# Altium schematic sheet RX Schematics.SchDoc
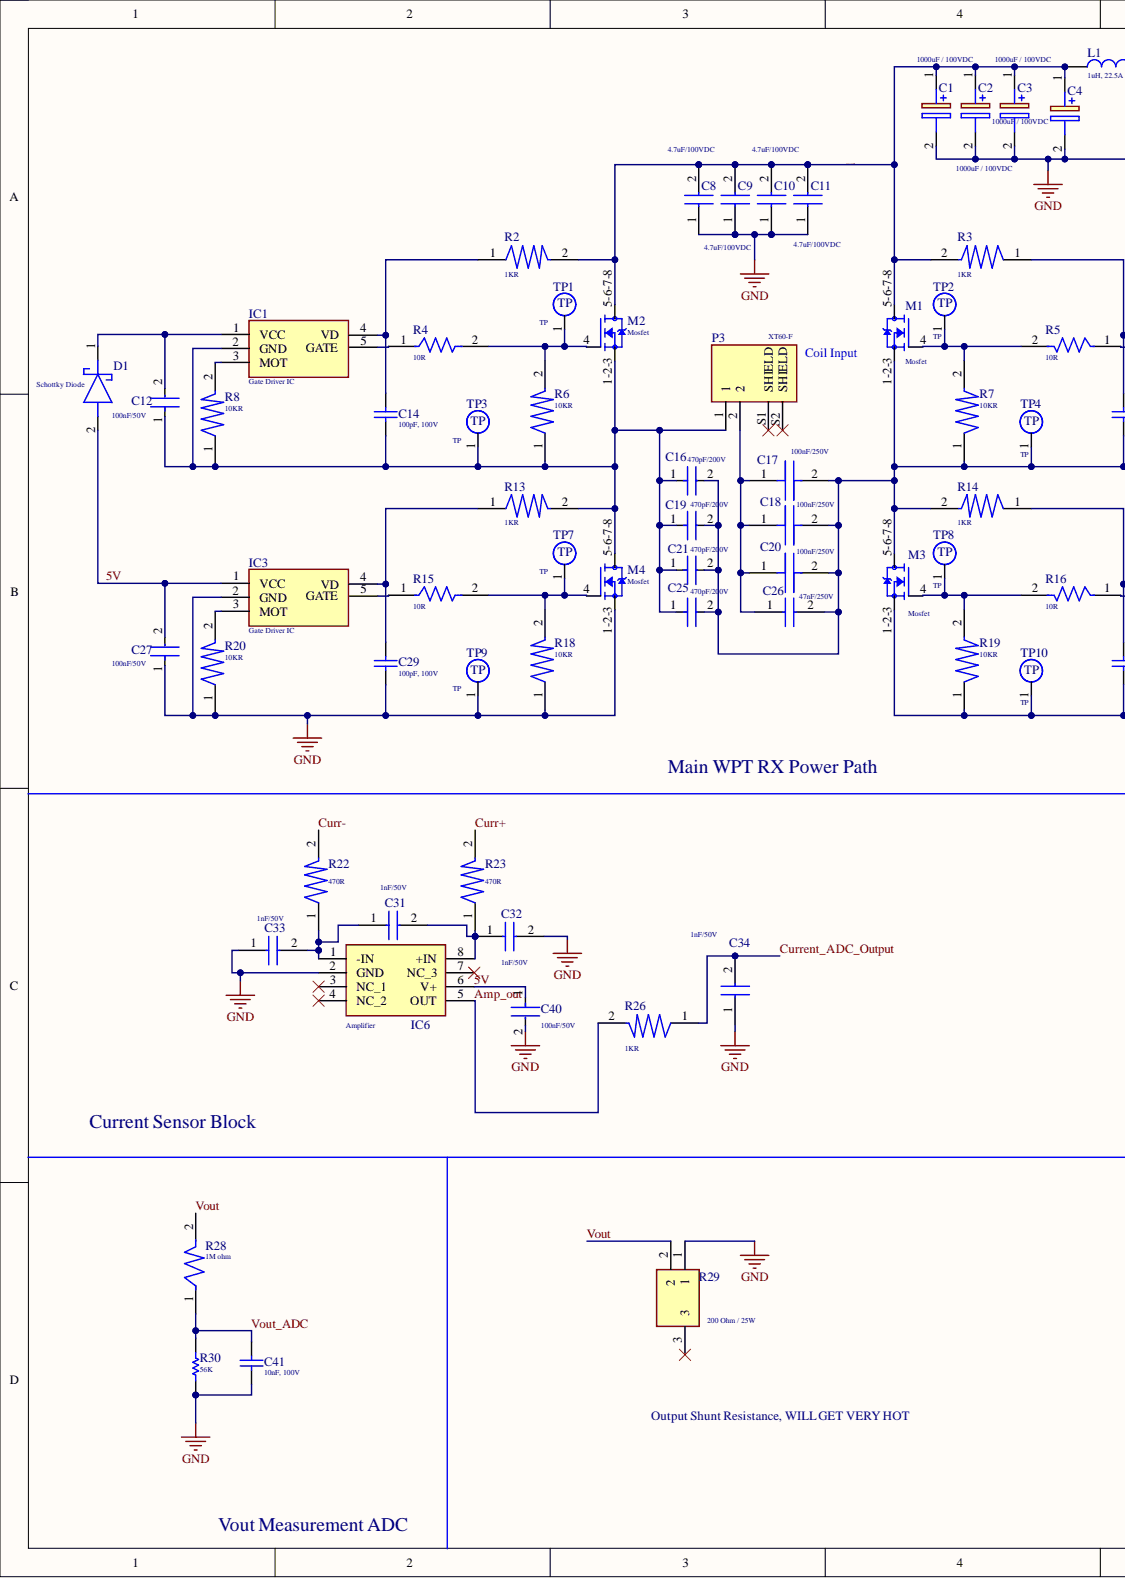
[diagram p0: RX Schematics.SchDoc, left]
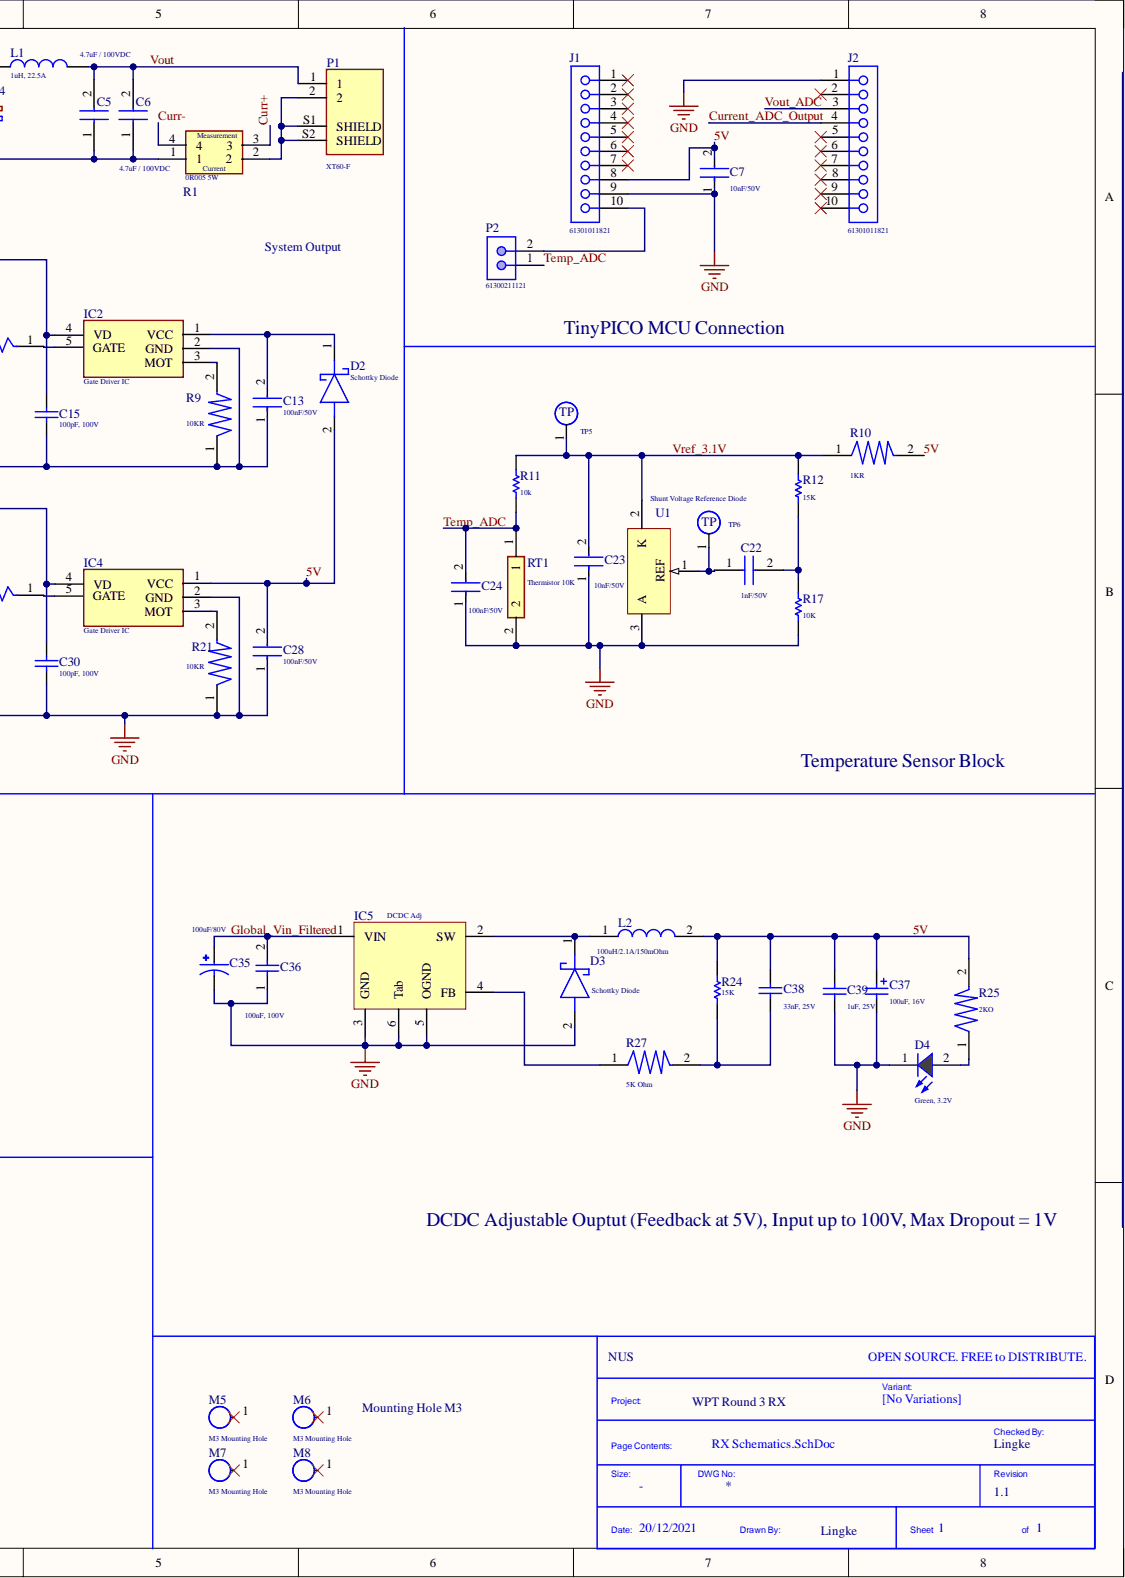
[diagram p1: RX Schematics.SchDoc, right]

## Parts
*1  Checked By: Lingke  Company: NUS  Project Name: WPT Round 3 RX  Revision: 1.1  (hidden comment: Title_Block)  (no BOM)
C1  1000uF / 100VDC  [CAPPRD750W80D1850H4200]
C2  1000uF / 100VDC  [CAPPRD750W80D1850H4200]
C3  1000uF / 100VDC  [CAPPRD750W80D1850H4200]
C4  1000uF / 100VDC  [CAPPRD750W80D1850H4200]
C5  4.7uF / 100VDC  [CAPC3225X280N]
C6  4.7uF / 100VDC  [CAPC3225X280N]
C7  10nF/50V  [0805 Capacitor]
C8  4.7uF/100VDC  [CAPC3225X280N]
C9  4.7uF/100VDC  [CAPC3225X280N]
C10  4.7uF/100VDC  [CAPC3225X280N]
C11  4.7uF/100VDC  [CAPC3225X280N]
C12  100nF/50V  [0805 Capacitor]
C13  100nF/50V  [0805 Capacitor]
C14  100pF, 100V  [WCAP-CSGP_0805_H=0.6mm_Reflow]
C15  100pF, 100V  [WCAP-CSGP_0805_H=0.6mm_Reflow]
C16  470pF/200V  [FP-C1206C-EB-MFG]
C17  100nF/250V  [CAPC4532X220N]
C18  100nF/250V  [CAPC4532X220N]
C19  470pF/200V  [FP-C1206C-EB-MFG]
C20  100nF/250V  [CAPC4532X220N]
C21  470pF/200V  [FP-C1206C-EB-MFG]
C22  1nF/50V  [CAPC2012X90N]
C23  10nF/50V  [0805 Capacitor]
C24  100nF/50V  [0805 Capacitor]
C25  470pF/200V  [FP-C1206C-EB-MFG]
C26  47nF/250V  [CAPC4532X350N]
C27  100nF/50V  [0805 Capacitor]
C28  100nF/50V  [0805 Capacitor]
C29  100pF, 100V  [WCAP-CSGP_0805_H=0.6mm_Reflow]
C30  100pF, 100V  [WCAP-CSGP_0805_H=0.6mm_Reflow]
C31  1nF/50V  [CAPC2012X90N]
C32  1nF/50V  [CAPC2012X90N]
C33  1nF/50V  [CAPC2012X90N]
C34  1nF/50V  [CAPC2012X90N]
C35  100uF/80V  [CAP_EEEFK1K101AV]
C36  100nF, 100V  [WCAP-CSGP_0805_H=1.25mm]
C37  100uF, 16V  [WCAP-ASLI_D6.3H5.5]
C38  33nF, 25V  [WCAP-CSGP_0805_H=0.8mm_Reflow]
C39  1uF, 25V  [WCAP-CSGP_0805_H=1.25mm]
C40  100nF/50V  [0805 Capacitor]
C41  10nF, 100V  [WCAP-CSGP_0805_H=0.8mm_Reflow]
D1  Schottky Diode  [RB400VAM50TR]
D2  Schottky Diode  [RB400VAM50TR]
D3  Schottky Diode  [DIONM8059X265N]
D4  Green, 3.2V  Forward Voltage typ.: 3.2V  [WL-SMCW_0805]
IC1  Gate Driver IC  [SOT95P280X145-5N]
IC2  Gate Driver IC  [SOT95P280X145-5N]
IC3  Gate Driver IC  [SOT95P280X145-5N]
IC4  Gate Driver IC  [SOT95P280X145-5N]
IC5  DCDC Adj  [TO-263-5]
IC6  Amplifier  [SOIC127P600X175-8N]
J1  61301011821  [61301011821]
J2  61301011821  [61301011821]
L1  1uH, 22.5A  Rated Current: 22.5A  [7847227010]
L2  100uH/2.1A/150mOhm  [INDPM125125X800N]
M1  Mosfet  [TRANS_BSZ037N06LS5ATMA1]
M2  Mosfet  [TRANS_BSZ037N06LS5ATMA1]
M3  Mosfet  [TRANS_BSZ037N06LS5ATMA1]
M4  Mosfet  [TRANS_BSZ037N06LS5ATMA1]
M5  M3 Mounting Hole  [M3 Hole]
M6  M3 Mounting Hole  [M3 Hole]
M7  M3 Mounting Hole  [M3 Hole]
M8  M3 Mounting Hole  [M3 Hole]
P1  XT60-F  [AMASS_XT60PW-F]
P2  61300211121  [61300211121]
P3  XT60-F  [AMASS_XT60PW-F]
R1  0R005 5W  [FC4L110R005FER]
R2  1KR  [FP-CRCW0805-e3-MFG]
R3  1KR  [FP-CRCW0805-e3-MFG]
R4  10R  [FP-CRCW1206-e3-IPC_A]
R5  10R  [FP-CRCW1206-e3-IPC_A]
R6  10KR  [FP-CRCW0805-e3-MFG]
R7  10KR  [FP-CRCW0805-e3-MFG]
R8  10KR  [FP-CRCW0805-e3-MFG]
R9  10KR  [FP-CRCW0805-e3-MFG]
R10  1KR  [FP-CRCW0805-e3-MFG]
R11  10k  [0805 Resistor]
R12  15K  [0805 Resistor]
R13  1KR  [FP-CRCW0805-e3-MFG]
R14  1KR  [FP-CRCW0805-e3-MFG]
R15  10R  [FP-CRCW1206-e3-IPC_A]
R16  10R  [FP-CRCW1206-e3-IPC_A]
R17  10K  [0805 Resistor]
R18  10KR  [FP-CRCW0805-e3-MFG]
R19  10KR  [FP-CRCW0805-e3-MFG]
R20  10KR  [FP-CRCW0805-e3-MFG]
R21  10KR  [FP-CRCW0805-e3-MFG]
R22  470R  [FP-CRCW0805-e3-MFG]
R23  470R  [FP-CRCW0805-e3-MFG]
R24  15K  [0805 Resistor]
R25  2KO  [FP-CRCW0805-e3-MFG]
R26  1KR  [FP-CRCW0805-e3-MFG]
R27  5K Ohm  [FP-CRCW0805-e3-MFG]
R28  1M ohm  [FP-CRCW0805-e3-MFG]
R29  200 Ohm / 25W  [PWR163S253000FE]
R30  56K  [0805 Resistor]
RT1  Thermistor 10K  [THRMC2012X95N]
TP1  TP  [Test Point]  (no BOM)
TP2  TP  [Test Point]  (no BOM)
TP3  TP  [Test Point]  (no BOM)
TP4  TP  [Test Point]  (no BOM)
TP5  TP  [Test Point]  (no BOM)
TP6  TP  [Test Point]  (no BOM)
TP7  TP  [Test Point]  (no BOM)
TP8  TP  [Test Point]  (no BOM)
TP9  TP  [Test Point]  (no BOM)
TP10  TP  [Test Point]  (no BOM)
U1  Shunt Voltage Reference Diode  [SOT23-3_N]

## Nets
GND: C1.2 C2.2 C3.2 C4.2 C5.1 C6.1 C7.1 C8.1 C9.1 C10.1 C11.1 C23.1 C24.1 C27.1 C28.1 C29.1 C30.1 C32.2 C33.1 C34.1 C35.2 C36.1 C37.2 C39.1 C40.2 C41.1 D3.2 D4.1 IC3.2(GND) IC4.2(GND) IC5.3(GND) IC5.5(OGND) IC5.6(Tab) IC6.2(GND) J1.9 J2.1 M3.1-2-3 M4.1-2-3 R1.1 R17.1 R18.1 R19.1 R20.1 R21.1 R29.1 R30.1 RT1.2 TP9.1 TP10.1 U1.3
5V: C7.2 C27.2 C28.2 C37.1 C38.2 C39.2 C40.1 D1.2 D2.2 IC3.1(VCC) IC4.1(VCC) IC6.6(V+) J1.8 L2.2 R10.2 R24.2 R25.2
Amp_out: IC6.5(OUT) R26.2
Curr+: R1.3 R23.2
Curr-: R1.4 R22.2
Current_ADC_Output: C34.2 J2.4 R26.1
Global_Vin_Filtered: C35.1 C36.2 IC5.1(VIN)
Temp_ADC: C24.2 P2.1 R11.1 RT1.1
Vout: C5.2 C6.2 L1.2 P1.1 R28.2 R29.2
Vout_ADC: C41.2 J2.3 R28.1 R30.2
Vout_Pre_Filter: C1.1 C2.1 C3.1 C4.1 C8.2 C9.2 C10.2 C11.2 L1.1 M1.5-6-7-8 M2.5-6-7-8 R2.2 R3.2
Vref_3.1V: C23.2 R10.1 R11.2 R12.2 TP5.1 U1.2
NetC12_1: C12.1 C14.1 C16.1 C19.1 C21.1 C25.1 IC1.2(GND) M2.1-2-3 M4.5-6-7-8 P3.1 R6.1 R8.1 R13.2 TP3.1
NetC12_2: C12.2 D1.1 IC1.1(VCC)
NetC13_1: C13.1 C15.1 C16.2 C17.2 C18.2 C19.2 C20.2 C21.2 C25.2 C26.2 IC2.2(GND) M1.1-2-3 M3.5-6-7-8 R7.1 R9.1 R14.2 TP4.1
NetC13_2: C13.2 D2.1 IC2.1(VCC)
NetC14_2: C14.2 IC1.4(VD) R2.1
NetC15_2: C15.2 IC2.4(VD) R3.1
NetC17_1: C17.1 C18.1 C20.1 C26.1 P3.2
NetC22_1: C22.1 TP6.1 U1.1
NetC22_2: C22.2 R12.1 R17.2
NetC29_2: C29.2 IC3.4(VD) R13.1
NetC30_2: C30.2 IC4.4(VD) R14.1
NetC31_1: C31.1 C33.2 IC6.1(-IN) R22.1
NetC31_2: C31.2 C32.1 IC6.8(+IN) R23.1
NetC38_1: C38.1 R24.1 R27.2
NetD3_1: D3.1 IC5.2(SW) L2.1
NetD4_2: D4.2 R25.1
NetIC1_3: IC1.3(MOT) R8.2
NetIC1_5: IC1.5(GATE) R4.1
NetIC2_3: IC2.3(MOT) R9.2
NetIC2_5: IC2.5(GATE) R5.1
NetIC3_3: IC3.3(MOT) R20.2
NetIC3_5: IC3.5(GATE) R15.1
NetIC4_3: IC4.3(MOT) R21.2
NetIC4_5: IC4.5(GATE) R16.1
NetIC5_4: IC5.4(FB) R27.1
NetJ1_10: J1.10 P2.2
NetM1_4: M1.4 R5.2 R7.2 TP2.1
NetM2_4: M2.4 R4.2 R6.2 TP1.1
NetM3_4: M3.4 R16.2 R19.2 TP8.1
NetM4_4: M4.4 R15.2 R18.2 TP7.1
NetP1_2: P1.2 P1.S1(SHIELD) P1.S2(SHIELD) R1.2

Not connected: IC6 3(NC_1) 4(NC_2) 7(NC_3); J1 1 2 3 4 5 6 7; J2 2 5 6 7 8 9 10; M5 1; M6 1; M7 1; M8 1; P3 S1(SHIELD) S2(SHIELD); R29 3

## Library data of the parts
*1 = Title_Block: Standard Title Block.; Library Name: WPT NUS.IntLib; Size: -; Drawn By: Lingke
C1-C4 = UPW2A102MHD: Capacitor Polarised; Manufacturer_Name: Nichicon; Arrow Part Number: UPW2A102MHD; Arrow Price/Stock: https://www.arrow.com/en/products/upw2a102mhd/nichicon; Component Kind: Standard; Datasheet Link: http://www.nichicon.co.jp/english/products/pdfs/e-pw.pdf; Height: 42mm; Manufacturer_Part_Number: UPW2A102MHD; Mouser Part Number: 647-UPW2A102MHD; Mouser Price/Stock: https://www.mouser.co.uk/ProductDetail/Nichicon/UPW2A102MHD/?qs=vtm6YctIBsEhKvWuRIWL3Q%3D%3D; Library Name: WPT NUS.IntLib
C5 C6 = CL32B475KCVZNWE: Capacitor; Manufacturer_Name: Samsung Electro-Mechanics; Component Kind: Standard; Height: 2.8mm; Manufacturer_Part_Number: CL32B475KCVZNWE; Library Name: WPT NUS.IntLib
C7 C23 = 885012207092: Component Kind: Standard; Description: 10nF/50V; Library Name: Symbol Library.SchLib; Supplier 1: Mouser; Supplier Part Number 1: 710-885012207092
C8-C11 = CNC6P1X7R2A475K250AE: Manufacturer_Name: TDK; Component Kind: Standard; Datasheet Link: https://componentsearchengine.com//CNC6P1X7R2A475K250AE.pdf; Description: 4.7uF/100VDC; Height: 2.8mm; Manufacturer_Part_Number: CNC6P1X7R2A475K250AE; Mouser2 Part Number: 810-CNC6P1X7R2A475K2; Mouser2 Price/Stock: https://www.mouser.com/Search/Refine.aspx?Keyword=810-CNC6P1X7R2A475K2; Supplier 1: Mouser; Supplier Part Number 1: 810-CNC6P1X7R2A475K2; Library Name: WPT NUS.IntLib
C12 C13 C24 C27 C28 C40 = 885012207098: Component Kind: Standard; Description: 100nF/50V; Supplier 1: Mouser; Supplier Part Number 1: 710-885012207098
  C24 C40: Library Name: Symbol Library.SchLib
  C12 C13 C27 C28: Library Name: WPT NUS.IntLib
C14 C15 C29 C30 = 885012007082: WCAP-CSGP Multilayer Ceramic Chip Capacitor, General Purpose, size 0805, NP0, 100pF, 100V; Manufacturer: Wurth Elektronik; Capacitance Tolerance: +/-5%; Isolation Resistance  min.: 10GOhm; Q-Factor min.: 1000; Rated Voltage: 100VDC; Technical Reference: NP00805101J100DFCT10000; Capacitance: 100pF; Ceramic Type: NP0; Component Kind: Standard; ComponentLink1Description: Manufacturer; ComponentLink1URL: http://www.we-online.de; ComponentLink2Description: Datasheet; ComponentLink2URL: https://www.we-online.com/catalog/datasheet/885012007082.pdf; Dissipation Factor max.: -; Height: 0.6mm; Library Name: Altium_WCAP-CSGP (rev21d).IntLib; Manufacturer Part Number: 885012007082; Mounting Technology: SMT; Operating Temperature: -55 to +125degC; Packaging: Tape and Reel; Published Date: April 2021; Size: 0805
C16 C19 C21 C25 = C1206C471K2GACTU: CAP CER 470PF 200V 10% NP0 1206; Manufacturer: KEMET; Automotive Grade: Grade 0; Material: Ceramic; Temperature Characteristic: C0G; Temperature Coefficient: 15%; Tolerance: 0.1; Automotive: Yes; Capacitance: 470 pF; Category: Cap; Component Kind: Standard; ComponentLink2Description: Datasheet; ComponentLink2URL: https://content.kemet.com/datasheets/KEM_C1007_X8R_ULTRA_150C_SMD.pdf; Device Class L1: Passive Components; Device Class L2: Capacitors; Device Class L3: Ceramic Capacitors; Digikey Description: CAP CER 470PF 200V 10% NP0 1206; Height: 0.88mm; Ipc Land Pattern Name: CAPC320160X78; Lead Free: Yes; Manufacturer Part Number: C1206C471K2GACTU; Mouser Description: Multilayer Ceramic Capacitors MLCC - SMD/SMT 200volts 470pF C0G 10%; Octopart: https://octopart.com/c1206c471k2gactu-kemet-3658280; Package: C1206C-EB; RoHS: TRUE; Temperature: -55°C to +150°C; Temperature Range High: +150°C; Temperature Range Low: -55°C; Value: 470 pF; Voltage Rating: 200 V; Library Name: WPT NUS.IntLib
C17 C18 C20 = C1812C104JAGACAUTO: Manufacturer_Name: Kemet; Component Kind: Standard; Datasheet Link: https://api.kemet.com/component-edge/download/datasheet/C1812C104JAGACAUTO.pdf; Description: 100nF/250V; Height: 2.2mm; Manufacturer_Part_Number: C1812C104JAGACAUTO; Supplier 1: Mouser; Supplier Part Number 1: 80-C1812C104JAGAUTO; Library Name: WPT NUS.IntLib
C22 C31-C34 = 885012207086: Manufacturer_Name: Wurth Elektronik; Component Kind: Standard; Datasheet Link: https://katalog.we-online.com/pbs/datasheet/885012207086.pdf; Description: 1nF/50V; Height: 0.9mm; Library Name: Symbol Library.SchLib; Manufacturer_Part_Number: 885012207086; Supplier 1: Mouser; Supplier Part Number 1: 710-885012207086
C26 = C4532C0G2E473J320KA: Capacitor  47nF/250V   C0G; Manufacturer_Name: TDK; Component Kind: Standard; Datasheet Link: https://product.tdk.com/info/en/catalog/datasheets/mlcc_commercial_midvoltage_en.pdf; Height: 3.5mm; Manufacturer_Part_Number: C4532C0G2E473J320KA; Supplier 1: Mouser; Supplier Part Number 1: 810-C4532C0G2E473J; Library Name: WPT NUS.IntLib
C35 = EEE-FK1K101AV: 100ÂµF 80V Aluminum Electrolytic Capacitors Radial, Can - SMD 320mOhm @ 100kHz 5000 Hrs @ 105Â°C; MF: Panasonic; MP: EEEFK1K101AV; Availability: In Stock; Check_prices: https://www.snapeda.com/parts/EEEFK1K101AV/Panasonic/view-part/5786341/?ref=eda; Component Kind: Standard; Library Name: Symbol Library.SchLib; Package: RADIAL-2 Panasonic; Price: None; Purchase-URL: https://pricing.snapeda.com/search/part/EEEFK1K101AV/?ref=eda; SnapEDA_Link: https://www.snapeda.com/parts/EEEFK1K101AV/Panasonic/view-part/5786341/?ref=snap
C36 = 885012207128: WCAP-CSGP Multilayer Ceramic Chip Capacitor, General Purpose, size 0805, X7R, 100nF, 100V; Manufacturer: Wurth Elektronik; Capacitance Tolerance: +/-10%; Dissipation Factor: #NAME?; Isolation Resistance: >=1GOhm; Rated Voltage: 100VDC; Technical Reference: X7R0805104K100DFCT10000; Capacitance: 100nF; Ceramic Type: X7R; Component Kind: Standard; ComponentLink1Description: Manufacturer; ComponentLink1URL: http://www.we-online.de; ComponentLink2Description: Datasheet; ComponentLink2URL: https://www.we-online.com/catalog/datasheet/885012207128.pdf; Height: 1.25mm; Library Name: Symbol Library.SchLib; Manufacturer Part Number: 885012207128; Mounting Technology: SMT; Operating Temperature: -55 to +125degC; Packaging: Tape and Reel; Published Date: May 2020; Size: 0805
C37 = 865080343009: WCAP-ASLI Aluminum Electrolytic Capacitor, V-Chip, SMT, D6.3xH5.5mm, 100uF, 16V; Manufacturer: Wurth Elektronik; Capacitance Tolerance: +/-20%; Dissipation Factor: 16%; Endurance: 2000h; Leakage Current: 16uA; Rated Voltage: 16VDC; Ripple Current: 255mA; Technical Reference: ASED055101M016DVCTBB000; Capacitance: 100uF; Component Kind: Standard; ComponentLink1Description: Manufacturer; ComponentLink1URL: http://www.we-online.de; ComponentLink2Description: Datasheet; ComponentLink2URL: https://www.we-online.com/catalog/datasheet/865080343009.pdf; Diameter: 6.3mm; Height: 5.5mm; Library Name: Altium_WCAP-ASLI (rev20b).IntLib; Manufacturer Part Number: 865080343009; Mounting Technology: SMT; Operating Temperature: -55 to +105degC; Packaging: Tape and Reel; Published Date: October 2020
C38 = 885012207069: WCAP-CSGP Multilayer Ceramic Chip Capacitor, General Purpose, size 0805, X7R, 33nF, 25V; Manufacturer: Wurth Elektronik; Capacitance Tolerance: +/-10%; Dissipation Factor max.: 3.5%; Isolation Resistance  min.: 10GOhm; Rated Voltage: 25VDC; Technical Reference: X7R0805333K025DFCT10000; Capacitance: 33nF; Ceramic Type: X7R; Component Kind: Standard; ComponentLink1Description: Manufacturer; ComponentLink1URL: http://www.we-online.de; ComponentLink2Description: Datasheet; ComponentLink2URL: https://www.we-online.com/catalog/datasheet/885012207069.pdf; Height: 0.8mm; Library Name: Altium_WCAP-CSGP (rev21d).IntLib; Manufacturer Part Number: 885012207069; Mounting Technology: SMT; Operating Temperature: -55 to +125degC; Packaging: Tape and Reel; Published Date: April 2021; Q-Factor min.: -; Size: 0805
C39 = 885012207078: WCAP-CSGP Multilayer Ceramic Chip Capacitor, General Purpose, size 0805, X7R, 1uF, 25V; Manufacturer: Wurth Elektronik; Capacitance Tolerance: +/-10%; Dissipation Factor max.: 5%; Isolation Resistance  min.: 0.5GOhm; Rated Voltage: 25VDC; Technical Reference: X7R0805105K025DFCT10000; Capacitance: 1uF; Ceramic Type: X7R; Component Kind: Standard; ComponentLink1Description: Manufacturer; ComponentLink1URL: http://www.we-online.de; ComponentLink2Description: Datasheet; ComponentLink2URL: https://www.we-online.com/catalog/datasheet/885012207078.pdf; Height: 1.25mm; Library Name: Altium_WCAP-CSGP (rev21d).IntLib; Manufacturer Part Number: 885012207078; Mounting Technology: SMT; Operating Temperature: -55 to +125degC; Packaging: Tape and Reel; Published Date: April 2021; Q-Factor min.: -; Size: 0805
C41 = 885012207122: WCAP-CSGP Multilayer Ceramic Chip Capacitor, General Purpose, size 0805, X7R, 10nF, 100V; Manufacturer: Wurth Elektronik; Capacitance Tolerance: +/-10%; Dissipation Factor max.: 2.5%; Isolation Resistance  min.: 10GOhm; Rated Voltage: 100VDC; Technical Reference: X7R0805103K100DFCT10000; Capacitance: 10nF; Ceramic Type: X7R; Component Kind: Standard; ComponentLink1Description: Manufacturer; ComponentLink1URL: http://www.we-online.de; ComponentLink2Description: Datasheet; ComponentLink2URL: https://www.we-online.com/catalog/datasheet/885012207122.pdf; Height: 0.8mm; Library Name: Altium_WCAP-CSGP (rev21d).IntLib; Manufacturer Part Number: 885012207122; Mounting Technology: SMT; Operating Temperature: -55 to +125degC; Packaging: Tape and Reel; Published Date: April 2021; Q-Factor min.: -; Size: 0805
D1 D2 = RB400VAM-50TR: Manufacturer_Name: ROHM Semiconductor; Component Kind: Standard; Datasheet Link: https://componentsearchengine.com//RB400VAM-50TR.pdf; Description: Schottky Diode; Height: 0.8mm; Manufacturer_Part_Number: RB400VAM-50TR; Mouser2 Part Number: 755-RB400VAM-50TR; Mouser2 Price/Stock: https://www.mouser.com/Search/Refine.aspx?Keyword=755-RB400VAM-50TR; Supplier 1: Mouser; Supplier Part Number 1: 755-RB400VAM-50TR; Library Name: WPT NUS.IntLib
D3 = S310: Manufacturer_Name: ON Semiconductor; Arrow Part Number: S310; Arrow Price/Stock: https://www.arrow.com/en/products/s310/on-semiconductor?region=nac; Component Kind: Standard; Datasheet Link: https://componentsearchengine.com/Datasheets/2/S310.pdf; Description: Schottky Diode; Height: 2.65mm; Library Name: Symbol Library.SchLib; Manufacturer_Part_Number: S310; Mouser Part Number: 512-S310; Mouser Price/Stock: https://www.mouser.co.uk/ProductDetail/ON-Semiconductor-Fairchild/S310?qs=AZz8fAaf6P%2FJSlbiibJLxA%3D%3D
D4 = 150080GS75000: WL-SMCW SMT Mono-color Chip LED Waterclear, size 0805, Green, 3.2V, 140deg; Chip Technology: InGaN; Dominant Wavelength typ.: 525nm; Luminous Intensity typ.: 450mcd; Peak Wavelength typ.: 515nm; Component Kind: Standard; ComponentLink1Description: Manufacturer; ComponentLink1URL: http://www.we-online.de; ComponentLink2Description: Datasheet; ComponentLink2URL: https://www.we-online.com/catalog/datasheet/150080GS75000.pdf; Emitting Color: Green; Library Name: Altium_WL-SMCW (rev20a).IntLib; Mounting Technology: SMT; Operating Temperature: -40 to +85degC; Packaging: Tape and Reel; Part Number: 150080GS75000; Published Date: December 2019; Size: 0805; Viewing Angle: 140deg; Publisher: Wurth Elektronik
IC1-IC4 = IR1161LTRPBF: Manufacturer_Name: Infineon; Component Kind: Standard; Description: Gate Driver IC; Height: 1.45mm; Manufacturer_Part_Number: IR1161LTRPBF; Supplier 1: Mouser; Supplier Part Number 1: 942-IR1161LTRPBF; Library Name: WPT NUS.IntLib
IC5 = XL7035E1: Component Kind: Standard; Library Name: Symbol Library.SchLib
IC6 = AD8215YRZ: Integrated Circuit Amplifier; Manufacturer_Name: Analog Devices; Component Kind: Standard; Datasheet Link: http://www.analog.com/static/imported-files/data_sheets/AD8215.pdf; Height: 1.75mm; Library Name: Symbol Library.SchLib; Manufacturer_Part_Number: AD8215YRZ; Supplier 1: Mouser; Supplier Part Number 1: 584-AD8215YRZ
J1 J2 = 61301011821: WR-PHD Socket Header, THT, pitch 2.54mm, Single Row, Vertical, 10p; Manufacturer: Wurth Elektronik; Contact Resistance: 20mOhm; Pin Number: 10; Rated Current: 3A; Withstanding Voltage: 500VAC; Working Voltage: 250VAC; Component Kind: Standard; ComponentLink1Description: Manufacturer; ComponentLink1URL: http://www.we-online.de; ComponentLink2Description: Datasheet; ComponentLink2URL: https://www.we-online.com/catalog/datasheet/61301011821.pdf; Gender: Socket Header; Library Name: Altium_WR-PHD (rev20a).IntLib; Manufacturer Part Number: 61301011821; Mounting Technology: THT; Operating Temperature: -40 to +125degC; Orientation: Vertical; Packaging: Tray; Pitch: 2.54mm; Published Date: May 2020; Row Number: Single
L1 = 7847227010: WE-RCIS SMT Rod Core Inductor, 1uH, 22.5A; Manufacturer: Wurth Elektronik; DC Resistance: 2mOhm; Saturation Current: 29A; Self Resonant Frequency: 213MHz; Component Kind: Standard; ComponentLink1Description: Manufacturer; ComponentLink1URL: http://www.we-online.de; ComponentLink2Description: Datasheet; ComponentLink2URL: https://www.we-online.com/catalog/datasheet/7847227010.pdf; Diameter: 7.1mm; Inductance: 1uH; Length: 15mm; Library Name: Altium_WE-RCIS (rev21a).IntLib; Manufacturer Part Number: 7847227010; Mounting Technology: SMT; Operating Temperature: -40 to +150degC; Packaging: Tape and Reel; Published Date: Feburary 2021
L2 = SRR1280-101M: Inductor; Manufacturer_Name: Bourns; Arrow Part Number: SRR1280-101M; Arrow Price/Stock: https://www.arrow.com/en/products/srr1280-101m/bourns; Component Kind: Standard; Datasheet Link: https://componentsearchengine.com/Datasheets/2/SRR1280-101M.pdf; Height: 8mm; Library Name: Symbol Library.SchLib; Manufacturer_Part_Number: SRR1280-101M; Mouser Part Number: 652-SRR1280-101M; Mouser Price/Stock: https://www.mouser.co.uk/ProductDetail/Bourns/SRR1280-101M?qs=brMhP%2FMjUfd8D85Ep5aW7g%3D%3D
M1-M4 = BSZ070N08LS5ATMA1: Further performance improvements with best-in-class OptiMOSâ„¢ 5 60V power MOSFET in high performance PQFN 3.3x3.3mm package  MOSFET Diode; MF: Infineon Technologies; MP: BSZ037N06LS5ATMA1; Availability: Unavailable; Component Kind: Standard; Package: TSDSON-8 Infineon Technologies; Price: None; SnapEDA_Link: https://www.snapeda.com/parts/BSZ037N06LS5ATMA1/Infineon%20Technologies/view-part/4422719/?ref=snap; Supplier 1: Mouser; Supplier Part Number 1: 726-BSZ070N08LS5ATMA; Library Name: WPT NUS.IntLib
M5-M8 = M3_Mounting_hole: Component Kind: Standard; Library Name: Symbol Library.SchLib
P1 P3 = XT60PW-F: Socket DC Supply Connector Female; MF: AMASS; Availability: Unavailable; BuyPart: https://www.snapeda.com/parts/XT60PW-F/AMASS/view-part/2890674/?ref=eda; Component Kind: Standard; MP: XT60PW-F; Package: Package; Price: None; SnapEDA_Link: https://www.snapeda.com/parts/XT60PW-F/AMASS/view-part/2890674/?ref=snap; Library Name: WPT NUS.IntLib
P2 = 61300211121: WR-PHD Pin Header, THT, pitch 2.54mm, Single Row, Vertical, 2p; Manufacturer: Wurth Elektronik; Contact Resistance: 20mOhm; Pin Number: 2; Rated Current: 3A; Withstanding Voltage: 500VAC; Working Voltage: 250VAC; Component Kind: Standard; ComponentLink1Description: Manufacturer; ComponentLink1URL: http://www.we-online.de; ComponentLink2Description: Datasheet; ComponentLink2URL: https://www.we-online.com/catalog/datasheet/61300211121.pdf; Gender: Pin Header; Library Name: Altium_WR-PHD (rev20a).IntLib; Manufacturer Part Number: 61300211121; Mounting Technology: THT; Operating Temperature: -40 to +105degC; Orientation: Vertical; Packaging: Bag; Pitch: 2.54mm; Published Date: May 2020; Row Number: Single
R1 = FC4L110R005FER: Resistor 0R005 5W; Manufacturer_Name: Ohmite; Component Kind: Standard; Height: 0.7mm; Manufacturer_Part_Number: FC4L110R005FER; Supplier 1: Mouser; Supplier Part Number 1: 588-FC4L110R005FER; Library Name: WPT NUS.IntLib
R2 R3 R10 R13 R14 R26 = CRCW08051K00FKEA: Resistor 1k Ohm; Component Kind: Standard; Number of Terminations: 2; Supplier 1: Mouser; Supplier Part Number 1: 71-CRCW0805-1.0K-E3
  R10 R26: Library Name: Symbol Library.SchLib
  R2 R3 R13 R14: Library Name: WPT NUS.IntLib
R4 R5 R15 R16 = CRCW120610R0FKEA: RES Thick Film, 10Ω, 1%, 0.25W, 100ppm/°C, 1206  MOSFET Gate Resistor 10Ohm; Max Power Dissipation: 250mW; Voltage Rating: 200V; Case Code (Imperial): 1206; Case Code (Metric): 3216; Case/Package: 1206; Component Kind: Standard; Composition: Thick Film; Contact Plating: Tin; Depth: 1.6mm; Features: Automotive AEC-Q200; Height: 0.55mm; Lead Free: Lead Free; Length: 3.2mm; Max Operating Temperature: 155°C; Military Standard: Not; Min Operating Temperature: -55°C; Number of Pins: 2; Number of Terminations: 2; Package Quantity: 10; Packaging: Tape and Reel; Power Rating: 250mW; Radiation Hardening: No; Resistance: 10R; Supplier 1: Digi-Key; Supplier Part Number 1: 541-10.0FDKR-ND; Temperature Coefficient: 100ppm/°C; Termination: SMD/SMT; Tolerance: 1%; Voltage Rating (DC): 200V; Weight: 0.000353oz; Width: 0.063inch; Library Name: WPT NUS.IntLib
R6-R9 R18-R21 = CRCW080510K0FKEA: Resistor 10K Ohm; Component Kind: Standard; Number of Terminations: 2; Supplier 1: Mouser; Supplier Part Number 1: 71-CRCW0805-10K-E3; Library Name: WPT NUS.IntLib
R11 = ERA-6ARB103V: High Presition and High reliability 10K Ohm Resistor; Component Kind: Standard; Library Name: Symbol Library.SchLib; Supplier 1: Mouser; Supplier Part Number 1: 667-ERA-6ARB103V
R12 = ERA-6ARW153V: High Presicion 15K Ohm; Component Kind: Standard; Library Name: Symbol Library.SchLib; Supplier 1: Mouser; Supplier Part Number 1: 667-ERA-6ARW153V
R17 = CRCW080510K0FKEB: High Presicion 10K Ohm; Component Kind: Standard; Library Name: Symbol Library.SchLib; Supplier 1: Newark; Supplier Part Number 1: 26R4046
R22 R23 = CRCW0805470RFKEB: Resistor 470 Ohm; Component Kind: Standard; Library Name: Symbol Library.SchLib; Number of Terminations: 2; Supplier 1: Mouser; Supplier Part Number 1: 71-CRCW0805470RFKEB
R24 = ERA-6AED153V: 15K Ohm Resistor; Component Kind: Standard; Supplier 1: Mouser; Supplier Part Number 1: 667-ERA-6AED153V; Library Name: WPT NUS.IntLib
R25 = CRCW08052K00FKEB: RES Thick Film, 2kΩ, 1%, 0.125W, 100ppm/°C, 0805; Max Power Dissipation: 125mW; Resistance: 2kR; Voltage Rating: 150V; Case Code (Imperial): 0805; Case Code (Metric): 2012; Case/Package: 0805; Component Kind: Standard; Composition: Thick Film; Contact Plating: Tin; Features: Automotive AEC-Q200; Height: 0.02inch; Lead Free: Lead Free; Length: 0.079inch; Library Name: Symbol Library.SchLib; Max Operating Temperature: 155°C; Min Operating Temperature: -55°C; Number of Pins: 2; Number of Terminations: 2; Package Quantity: 10000; Packaging: Tape and Reel; Power Rating: 125mW; Radiation Hardening: No; Supplier 1: Mouser; Supplier Part Number 1: 71-CRCW08052K00FKEB; Temperature Coefficient: 100ppm/°C; Termination: SMD/SMT; Tolerance: 1%; Voltage Rating (DC): 150V; Weight: 0.000194oz; Width: 0.049inch
R27 = CRCW08055K00FKTA: Resistor 5K Ohm; Component Kind: Standard; Library Name: Symbol Library.SchLib; Number of Terminations: 2; Supplier 1: Mouser; Supplier Part Number 1: 71-CRCW08051K30FKEB
R28 = CRCW08051M00FKEA: RES Thick Film, 1MΩ, 1%, 0.125W, 100ppm/°C, 0805  1M Ohm Resistor; Power Rating: 125mW; Resistance: 1MR; Voltage Rating: 150V; Case Code (Imperial): 0805; Case Code (Metric): 2012; Case/Package: 0805; Component Kind: Standard; Composition: Thick Film; Contact Plating: Tin; Depth: 1.25mm; Height: 0.45mm; Lead Free: Lead Free; Length: 2mm; Max Operating Temperature: 155°C; Military Standard: Not; Min Operating Temperature: -55°C; Mount: Surface Mount; Number of Pins: 2; Package Quantity: 5000; Packaging: Tape and Reel; Radiation Hardening: No; Supplier 1: Mouser; Supplier Part Number 1: 71-CRCW08051M00FKEAH; Temperature Coefficient: 100ppm/°C; Termination: SMD/SMT; Tolerance: 1%; Voltage Rating (DC): 150V; Weight: 0.000194oz; Width: 1.25mm; Library Name: WPT NUS.IntLib
R29 = PWR163S-25-2000FE: Resistor 200 Ohm / 25W; Manufacturer_Name: Bourns; Manufacturer_Part_Number: PWR163S-25-3000FE; Component Kind: Standard; Datasheet Link: https://componentsearchengine.com/Datasheets/1/PWR163S-25-3000FE.pdf; Height: 2.79mm; Supplier 1: Mouser; Supplier Part Number 1: 652-PWR163S253000FE; Library Name: WPT NUS.IntLib
R30 = ERJ-6ENF5622V: 56K Ohm Resistor; Component Kind: Standard; Supplier 1: Mouser; Supplier Part Number 1: 667-ERJ-6ENF5622V; Library Name: WPT NUS.IntLib
RT1 = NTCS0805E3103HMT: Thermistor 10Kohm B Parameter: 3950k; Manufacturer_Name: Vishay; Component Kind: Standard; Datasheet Link: http://www.vishay.com/docs/29044/ntcs0805e3t.pdf; Height: 0.95mm; Library Name: Symbol Library.SchLib; Manufacturer_Part_Number: NTCS0805E3103HMT; Supplier 1: Mouser; Supplier Part Number 1: 594-NTCS0805E3103HMT
TP1-TP10 = Test Point: Test Point 1mm by 1mm
  TP5 TP6: Library Name: Symbol Library.SchLib
  TP1-TP4 TP7-TP10: Library Name: WPT NUS.IntLib
U1 = TS432AILT: Shunt voltage reference, adjustable, 1.24 to 10V, 0.5% precision, 3-Pin SOT23-3L, Tape and Reel; Component Kind: Standard; ComponentLink1Description: Manufacturer URL; ComponentLink1URL: http://www.st.com; ComponentLink2Description: Datasheet; ComponentLink2URL: http://www.st.com/internet/com/TECHNICAL_RESOURCES/TECHNICAL_LITERATURE/DATASHEET/CD00003202.pdf; DatasheetVersion: Apr-2008; Library Name: Symbol Library.SchLib; PackageDescription: 3-Pin SOT-23 Package 0.95 mm Pitch, 2.4 mm Lead Span; PackageReference: SOT23-3; PackageVersion: 2011; Supplier 1: Digi-Key; Supplier Part Number 1: 497-TS432AILTCT-ND
